# Altium schematic sheet payloadSheet1.SchDoc(payloadSheet1)
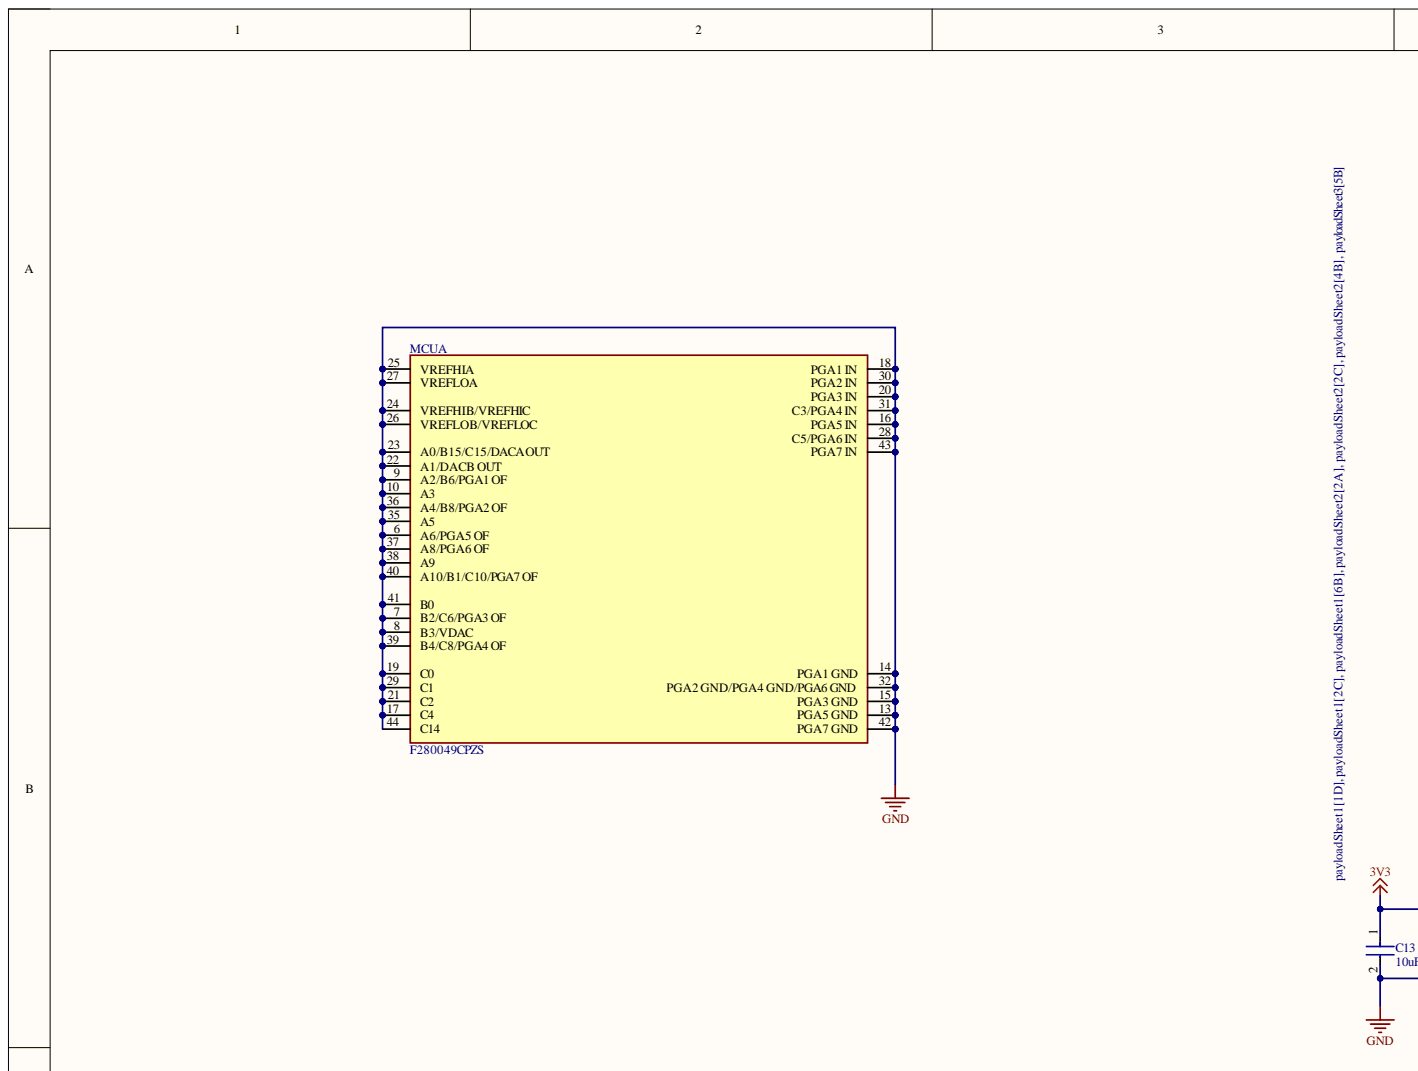
[diagram p0: payloadSheet1.SchDoc, top left]
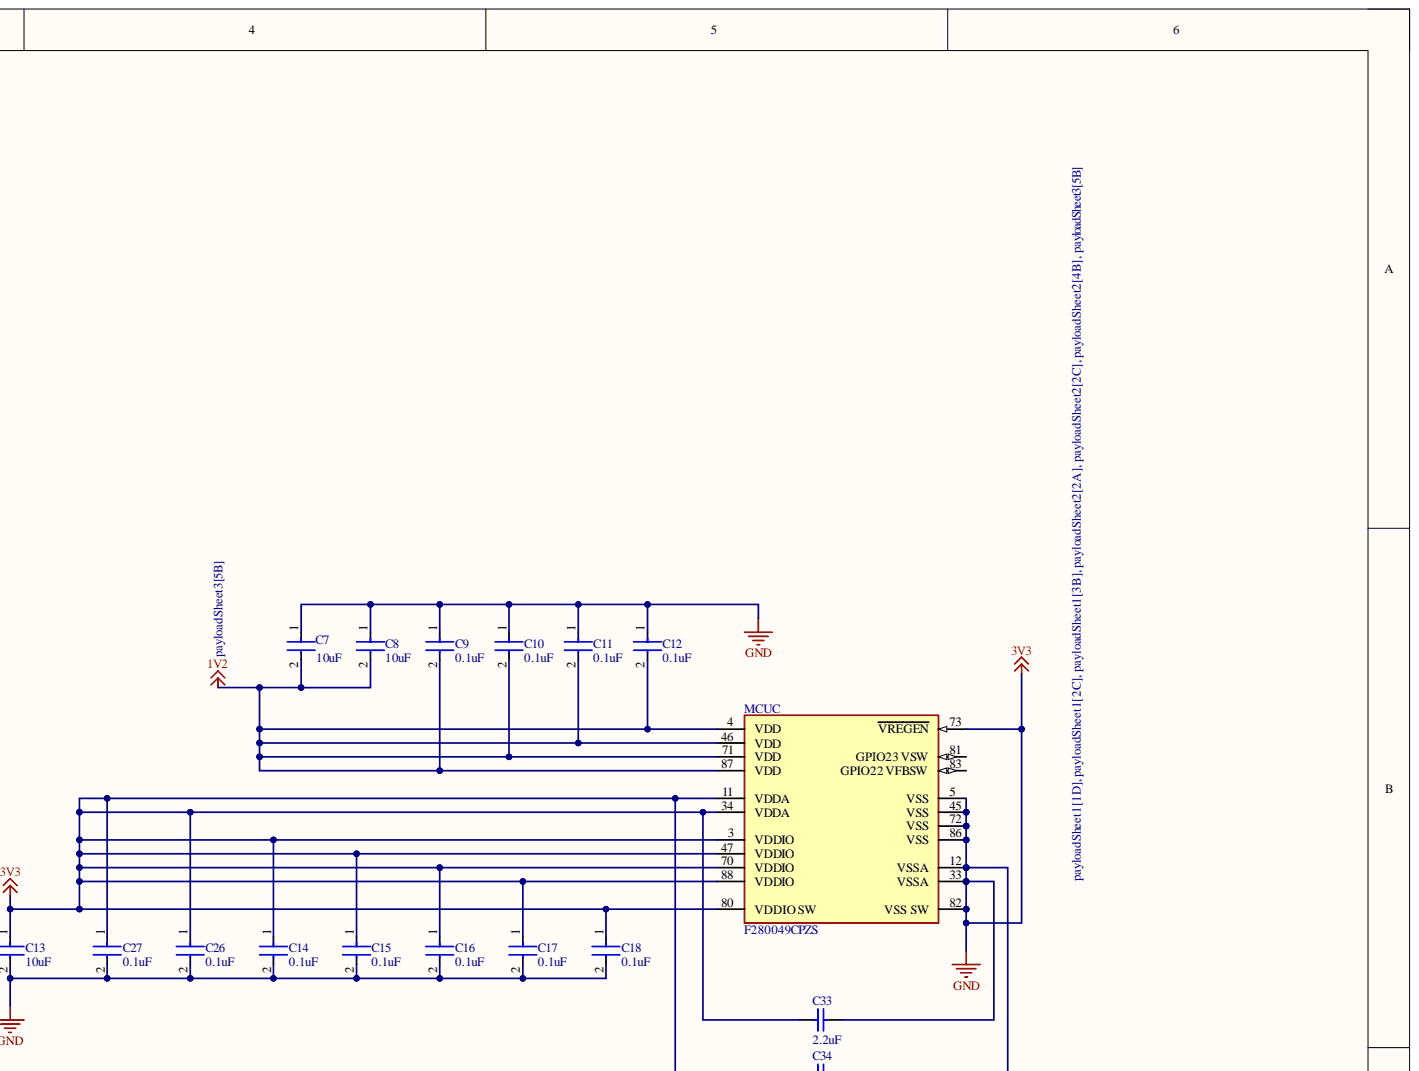
[diagram p1: payloadSheet1.SchDoc, top right]
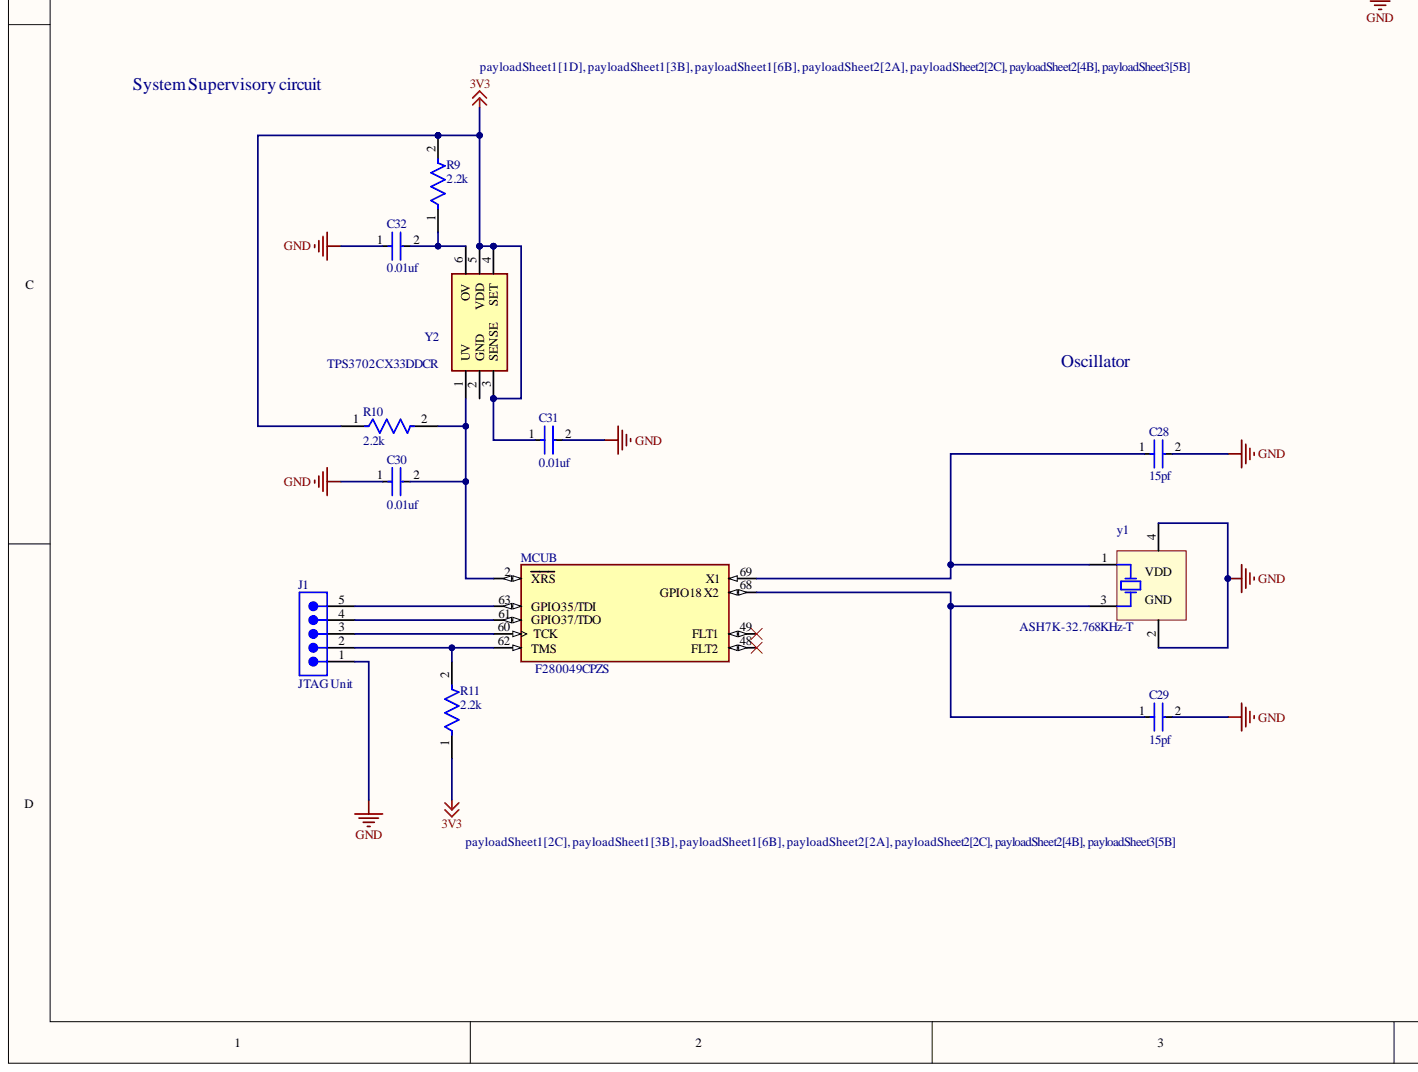
[diagram p2: payloadSheet1.SchDoc, bottom left]
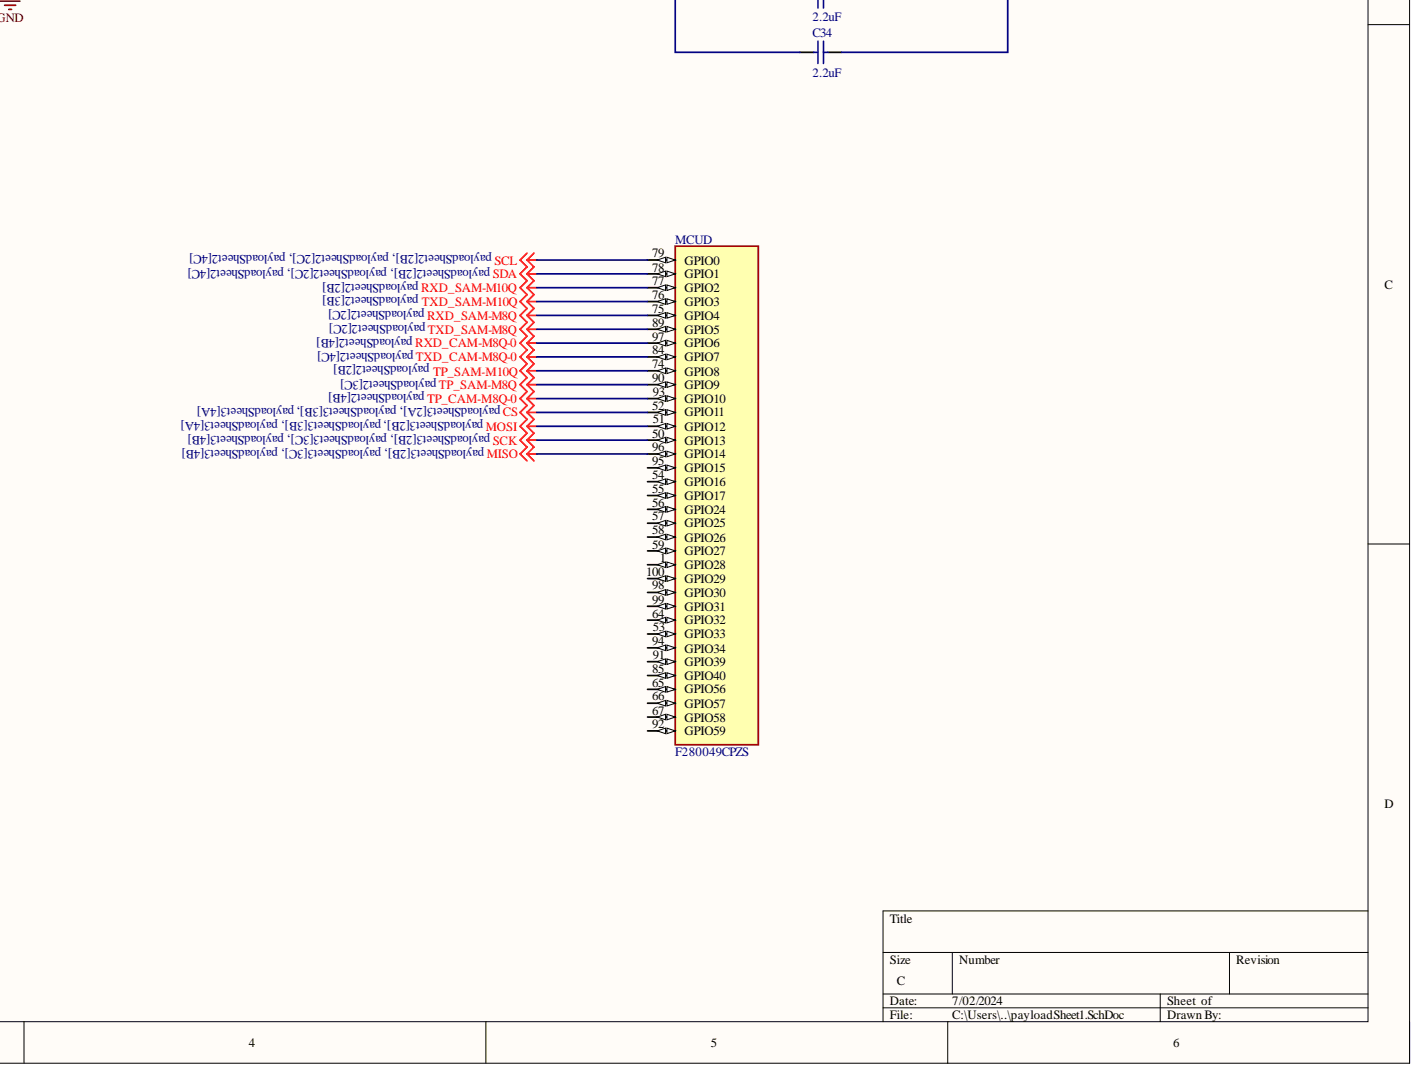
[diagram p3: payloadSheet1.SchDoc, bottom right]

## Parts
C7  10uF  [FP-GRM188-0_1-e0_2_0_55-IPC_A]
C8  10uF  [FP-GRM188-0_1-e0_2_0_55-IPC_A]
C9  0.1uF  [FP-CC0402-MFG]
C10  0.1uF  [FP-CC0402-MFG]
C11  0.1uF  [FP-CC0402-MFG]
C12  0.1uF  [FP-CC0402-MFG]
C13  10uF  [FP-GRM188-0_1-e0_2_0_55-IPC_A]
C14  0.1uF  [FP-CC0402-MFG]
C15  0.1uF  [FP-CC0402-MFG]
C16  0.1uF  [FP-CC0402-MFG]
C17  0.1uF  [FP-CC0402-MFG]
C18  0.1uF  [FP-CC0402-MFG]
C26  0.1uF  [FP-CC0402-MFG]
C27  0.1uF  [FP-CC0402-MFG]
C28  15pf  [FP-C0603C-CF-MFG]
C29  15pf  [FP-C0603C-CF-MFG]
C30  0.01uf  [FP-GRM033-0_03-IPC_B]
C31  0.01uf  [FP-GRM033-0_03-IPC_B]
C32  0.01uf  [FP-GRM033-0_03-IPC_B]
C33  2.2uF  [CAPC2013X145X50ML20T25]
C34  2.2uF  [CAPC2013X145X50ML20T25]
J1  JTAG Unit  [61300511121]
MCU  F280049CPZS  [FP-PZ0100A-IPC_C]  (parts A,B,C,D)
R9  2.2k  [FP-SR0603-MFG]
R10  2.2k  [FP-SR0603-MFG]
R11  2.2k  [FP-SR0603-MFG]
y1  ASH7K-32.768KHz-T  [ABRA-ASH7K-4_V]
Y2  TPS3702CX33DDCR  [TPS3702CX33DDCR]

## Nets
1V2: C7.2 C8.2 C9.2 C10.2 C11.2 C12.2 MCU.4(VDD) MCU.46(VDD) MCU.71(VDD) MCU.87(VDD)
3V3: C7.1 C8.1 C9.1 C10.1 C11.1 C12.1 C13.1 C13.2 C14.1 C14.2 C15.1 C15.2 C16.1 C16.2 C17.1 C17.2 C18.1 C18.2 C26.1 C26.2 C27.1 C27.2 C28.2 C29.2 C30.1 C31.1 C31.2 C32.1 C33.1 C33.2 C34.1 C34.2 J1.1 MCU.3(VDDIO) MCU.5(VSS) MCU.6(A6/PGA5_OF) MCU.7(B2/C6/PGA3_OF) MCU.8(B3/VDAC) MCU.9(A2/B6/PGA1_OF) MCU.10(A3) MCU.11(VDDA) MCU.12(VSSA) MCU.13(PGA5_GND) MCU.14(PGA1_GND) MCU.15(PGA3_GND) MCU.16(PGA5_IN) MCU.17(C4) MCU.18(PGA1_IN) MCU.19(C0) MCU.20(PGA3_IN) MCU.21(C2) MCU.22(A1/DACB_OUT) MCU.23(A0/B15/C15/DACA_OUT) MCU.24(VREFHIB/VREFHIC) MCU.25(VREFHIA) MCU.26(VREFLOB/VREFLOC) MCU.27(VREFLOA) MCU.28(C5/PGA6_IN) MCU.29(C1) MCU.30(PGA2_IN) MCU.31(C3/PGA4_IN) MCU.32(PGA2_GND/PGA4_GND/PGA6_GND) MCU.33(VSSA) MCU.34(VDDA) MCU.35(A5) MCU.36(A4/B8/PGA2_OF) MCU.37(A8/PGA6_OF) MCU.38(A9) MCU.39(B4/C8/PGA4_OF) MCU.40(A10/B1/C10/PGA7_OF) MCU.41(B0) MCU.42(PGA7_GND) MCU.43(PGA7_IN) MCU.44(C14) MCU.45(VSS) MCU.47(VDDIO) MCU.70(VDDIO) MCU.72(VSS) MCU.73(VREGEN) MCU.80(VDDIO_SW) MCU.82(VSS_SW) MCU.86(VSS) MCU.88(VDDIO) R9.2 R10.1 R11.1 y1.2 y1.4 Y2.3(SENSE) Y2.4(SET) Y2.5(VDD)
CS: MCU.52(GPIO11)
MISO: MCU.96(GPIO14)
MOSI: MCU.51(GPIO12)
RXD_CAM-M8Q-0: MCU.97(GPIO6)
RXD_SAM-M8Q: MCU.75(GPIO4)
RXD_SAM-M10Q: MCU.77(GPIO2)
SCK: MCU.50(GPIO13)
SCL: MCU.79(GPIO0)
SDA: MCU.78(GPIO1)
TP_CAM-M8Q-0: MCU.93(GPIO10)
TP_SAM-M8Q: MCU.90(GPIO9)
TP_SAM-M10Q: MCU.74(GPIO8)
TXD_CAM-M8Q-0: MCU.84(GPIO7)
TXD_SAM-M8Q: MCU.89(GPIO5)
TXD_SAM-M10Q: MCU.76(GPIO3)
NetC28_1: C28.1 MCU.69(X1) y1.1
NetC29_1: C29.1 MCU.68(GPIO18_X2) y1.3
NetC30_2: C30.2 MCU.2(XRS) R10.2 Y2.1(UV)
NetC32_2: C32.2 R9.1 Y2.6(OV)
NetJ1_2: J1.2 MCU.62(TMS) R11.2
NetJ1_3: J1.3 MCU.60(TCK)
NetJ1_4: J1.4 MCU.61(GPIO37/TDO)
NetJ1_5: J1.5 MCU.63(GPIO35/TDI)

Not connected: MCU 1(GPIO28) 48(FLT2) 49(FLT1) 53(GPIO33) 54(GPIO16) 55(GPIO17) 56(GPIO24) 57(GPIO25) 58(GPIO26) 59(GPIO27) 64(GPIO32) 65(GPIO56) 66(GPIO57) 67(GPIO58) 81(GPIO23_VSW) 83(GPIO22_VFBSW) 85(GPIO40) 91(GPIO39) 92(GPIO59) 94(GPIO34) 95(GPIO15) 98(GPIO30) 99(GPIO31) 100(GPIO29); Y2 2(GND)

## Library data of the parts
C7 C8 C13 = CMP-2006-02792-2: Chip Multilayer Ceramic Capacitors for General Purpose, 0603, 10uF, X5R, 15%, 20%, 6.3V; Capacitance: 10uF; Case Code (Imperial): 0603; Case Code (Metric): 1608; Case/Package: 0603; Component Kind: Standard; Depth: 0.8mm; Dielectric: X5R; Height: 0.8mm; Lead Free: Lead Free; Length: 1.6mm; Library Name: Altium Content Vault; Material: Ceramic; Max Operating Temperature: 85°C; Min Operating Temperature: -55°C; Mount: Surface Mount; Package Quantity: 4000; Packaging: Tape and Reel; Radiation Hardening: No; REACH SVHC: No SVHC; Termination: SMD/SMT; Thickness: 0.035inch; Tolerance: 20%; Voltage: 6.3V; Voltage Rating: 6.3V; Voltage Rating (DC): 6.3V; Width: 0.031inch
C9-C12 C14-C18 C26 C27 = CMP-03422-000048-1: CAP CER 0.1UF 16V X7R 0402; Manufacturer: YAGEO; Manufacturer Part Number: CC0402KRX7R7BB104; Automotive Grade: NA; Capacitance: 100 nF; Material: Ceramic; Temperature Coefficient: 30ppm/°C; Tolerance: 0.1; Value: 100 nF; Automotive: No; Category: Cap; Component Kind: Standard; ComponentLink2Description: Datasheet; ComponentLink2URL: http://www.yageo.com/documents/recent/UPY-GP_NP0_16V-to-50V_16.pdf; Device Class L1: Passive Components; Device Class L2: Capacitors; Device Class L3: Ceramic Capacitors; Digikey Description: CAP CER 0.1UF 16V X7R 0402; Footprint Url: http://www.yageo.com/exep/pages/download/literatures/UPY-C_GEN_24.pdf; Height: 0.55mm; Ipc Land Pattern Name: CAPC10050X50; Lead Free: Yes; Library Name: Altium Content Vault; Mouser Description: 0402 0.1 uF 16 V ±10 % Tolerance X7R SMT Multilayer Ceramic Capacitor; Octopart: https://octopart.com/cc0402krx7r7bb104-yageo-42244624; Package: CC0402; RoHS: TRUE; Temperature: -55°C to +125°C; Temperature Characteristic: X7R; Temperature Range High: +125°C; Temperature Range Low: -55°C; Voltage Rating: 16 V
C28 C29 = CMP-1035-00664-2: CAP CER 15PF 10V 20% NP0 0603; Manufacturer: KEMET; Manufacturer Part Number: C0603C150M8GACTU; Automotive Grade: Grade 1; Material: Ceramic; Temperature Characteristic: C0G; Temperature Coefficient: 30ppm/°C; Tolerance: 0.2; Automotive: Yes; Capacitance: 15 pF; Category: Cap; Component Kind: Standard; ComponentLink2Description: Datasheet; ComponentLink2URL: https://api.kemet.com/component-edge/download/datasheet/C0603C100J5GACAUTO.pdf; Device Class L1: Passive Components; Device Class L2: Capacitors; Device Class L3: Ceramic Capacitors; Digikey Description: CAP CER 15PF 10V 20% NP0 0603; Height: 0.87mm; Lead Free: Yes; Library Name: Altium Content Vault; Mouser Description: Multilayer Ceramic Capacitors MLCC - SMD/SMT 10volts 15pF C0G 20%; Octopart: https://octopart.com/c0603c150m8gactu-kemet-9139873; Package: C0603C-CF; RoHS: TRUE; Temperature: -55°C to +125°C; Temperature Range High: +125°C; Temperature Range Low: -55°C; Value: 15 pF; Voltage Rating: 10 V
C30-C32 = CMP-2010-01684-2: Chip Multilayer Ceramic Capacitors for General Purpose, 0201, 10000pF, X7R, 15%, 10%, 10V; Capacitance: 10nF; Case Code (Imperial): 0201; Case Code (Metric): 0603; Case/Package: 0201; Component Kind: Standard; Depth: 0.3mm; Dielectric: X7R; Height: 0.3mm; Lead Free: Lead Free; Length: 0.6mm; Library Name: Altium Content Vault; Material: Ceramic; Max Operating Temperature: 125°C; Min Operating Temperature: -55°C; Mount: Surface Mount; Package Quantity: 1; Packaging: Tape and Reel; Radiation Hardening: No; REACH SVHC: No SVHC; Termination: SMD/SMT; Thickness: 0.013inch; Tolerance: 10%; Voltage: 10V; Voltage Rating: 10V; Voltage Rating (DC): 10V; Width: 0.012inch
C33 C34 = CMP-1036-04819-2: Manufacturer: Kemet; Part Number: C0805C225K9PACTU; Component Kind: Standard; Library Name: Altium Content Vault
J1 = CMP-1502-01068-3: NexarPartId: 32855526; Connector Type: Male Pin; Contact Resistance: 20mΩ; Current Rating: 3A; Gender: Male; Number of Contacts: 5; Operating Supply Voltage: 250V; Pitch: 2.54mm; Color: Black; Component Kind: Standard; Contact Material: Copper; Contact Mating Length: 5.9944mm; Contact Plating: Gold; Height: 8.89mm; Lead Free: Lead Free; Lead Pitch: 2.54mm; Library Name: Altium Content Vault; Max Operating Temperature: 105°C; Min Operating Temperature: -40°C; Mount: Through Hole; Number of Pins: 5; Number of Positions: 5; Number of Rows: 1; Orientation: Vertical; Packaging: Bulk; REACH SVHC: Unknown; RoHS: Compliant; Termination: Solder; Voltage Rating: 250V
MCU = CMP-04869-000052-1: MICROCONTROLLER ARM CORTEX; Number of UART Channels: 2; Component Kind: Standard; Library Name: Altium Content Vault; Max Operating Temperature: 125°C; Min Operating Temperature: -40°C; RoHS Compliant: Yes
R9-R11 = CMP-03412-009794-1: RES 2.2K OHM 1% 1/4W 0603; Manufacturer: Yageo; Manufacturer Part Number: SR0603FR-7T2K2L; Automotive Grade: Grade 0; Power Rating: 0.25W; Resistance: 2.2KΩ; Tolerance: 0.01; Value: 2.2KΩ; Voltage Rating: 75V; Automotive: Yes; Category: Res; Component Kind: Standard; ComponentLink2Description: Datasheet; ComponentLink2URL: http://www.yageo.com/documents/recent/PYu-SR_D_F%20tol_RoHS_L_3.pdf; Composition: Thick Film; Device Class L1: Passive Components; Device Class L2: Resistors; Device Class L3: Chip SMD Resistors; Digikey Description: RES 2.2K OHM 1% 1/4W 0603; Footprint Url: http://www.yageo.com/exep/pages/download/literatures/PYu-R_Mount_10.pdf; Height: 0.55mm; Ipc Land Pattern Name: RESC16080X45; Lead Free: Yes; Library Name: Altium Content Vault; Octopart: https://octopart.com/sr0603fr-7t2k2l-yageo-92673854; Package: SR0603; RoHS: TRUE; Series: SR; Temperature: -55°C to +155°C; Temperature Range High: +155°C; Temperature Range Low: -55°C
y1 = CMP-0448-00001-2: Crystal Oscillator, 32.768 kHz, -40 to 80 degC, 3.2 x 1.5 x 1.0 mm SMD, Tape and Reel; Manufacturer: Abracon; Disable Delay Time (Max): 1 us; Fall Time (Max): 50 ns; Frequency Tolerance: -18 to 28 ppm; Output Load (CMOS): 15 pF; Start-up Time (Max): 0.6 s; Supply Voltage Range (Vdd): 1.5 to 36 V; Vdd Current Consumption (Max): 0.7 uA; VIO Current Consumption (Max): 3.5 uA; Component Kind: Standard; ComponentLink1Description: Manufacturer URL; ComponentLink1URL: http://www.abracon.com/; ComponentLink2Description: Datasheet; ComponentLink2URL: http://www.abracon.com/Oscillators/ASH7K.pdf; ComponentLink3Description: Package Specification; ComponentLink3URL: http://www.abracon.com/Oscillators/ASH7K.pdf; DatasheetVersion: 12.27.11; Frequency: 32.768 kHz; Library Name: Altium Content Vault; Mounting Technology: Surface Mount; Operating Temperature: -40 to 85 degC; PackageDescription: 4-Pin Hand Drawn, Body 3.2x1.5mm; PackageReference: ASH7K-4; Packing: Tape and Reel; PartNumber: ASH7K-32.768KHz-T; Rise Time(Max): 50 ns; Supply Voltage Range (VIO): 1.5 to 36 V
Y2 = CMP-00006-1: Power Supply Support Circuit, Adjustable, 1 Channel, 3.6, PDSO6; Adjustable Threshold: Yes; Channels: 1; Max Supply Voltage: 18V; Min Supply Voltage: 2V; Nominal Supply Voltage: 5V; Package Body Material: Plastic; Package Equivalence Code: TSSOP6,.08; Case/Package: TSSOP; Component Kind: Standard; Height - Seated (Max): 1.1mm; JESD-30 Code: R-PDSO-G6; JESD-609 Code: e4; Length: 2.9mm; Max Operating Temperature: 125°C; Min Operating Temperature: -40°C; Moisture Sensitivity Level: 2; Number of Channels: 1; Package Shape: Rectangular; Package Style: SMALL OUTLINE, THIN PROFILE, SHRINK PITCHMeter; Pins: 6; Reach SVHC Compliant: Yes; RoHS Compliant: Yes; Surface Mount: Yes; Temperature Grade: AUTOMOTIVE; Terminal Finish: Nickel; Terminal Form: Gull Wing; Terminal Pitch: 0.95mm; Terminal Position: DUAL; Throw Configuration: POWER SUPPLY SUPPORT CIRCUIT; Width: 1.6mm; Library Name: COSMIAC DJG
